# Altium schematic sheet 14-USB_Hub.SchDoc(14-USB_Hub)
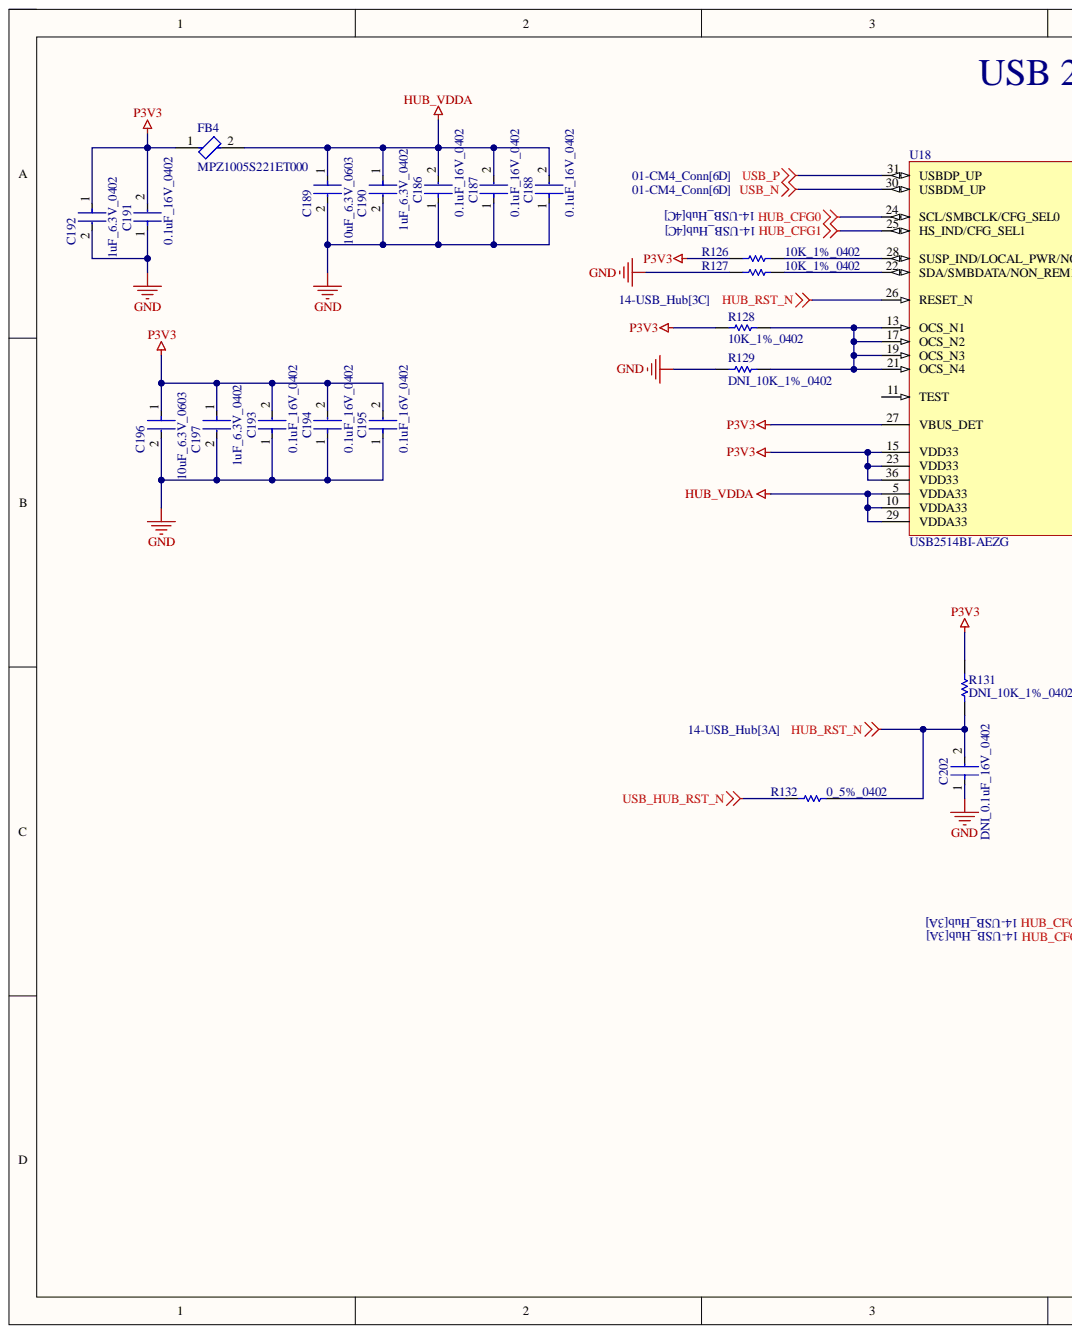
[diagram p0: 14-USB_Hub.SchDoc, left]
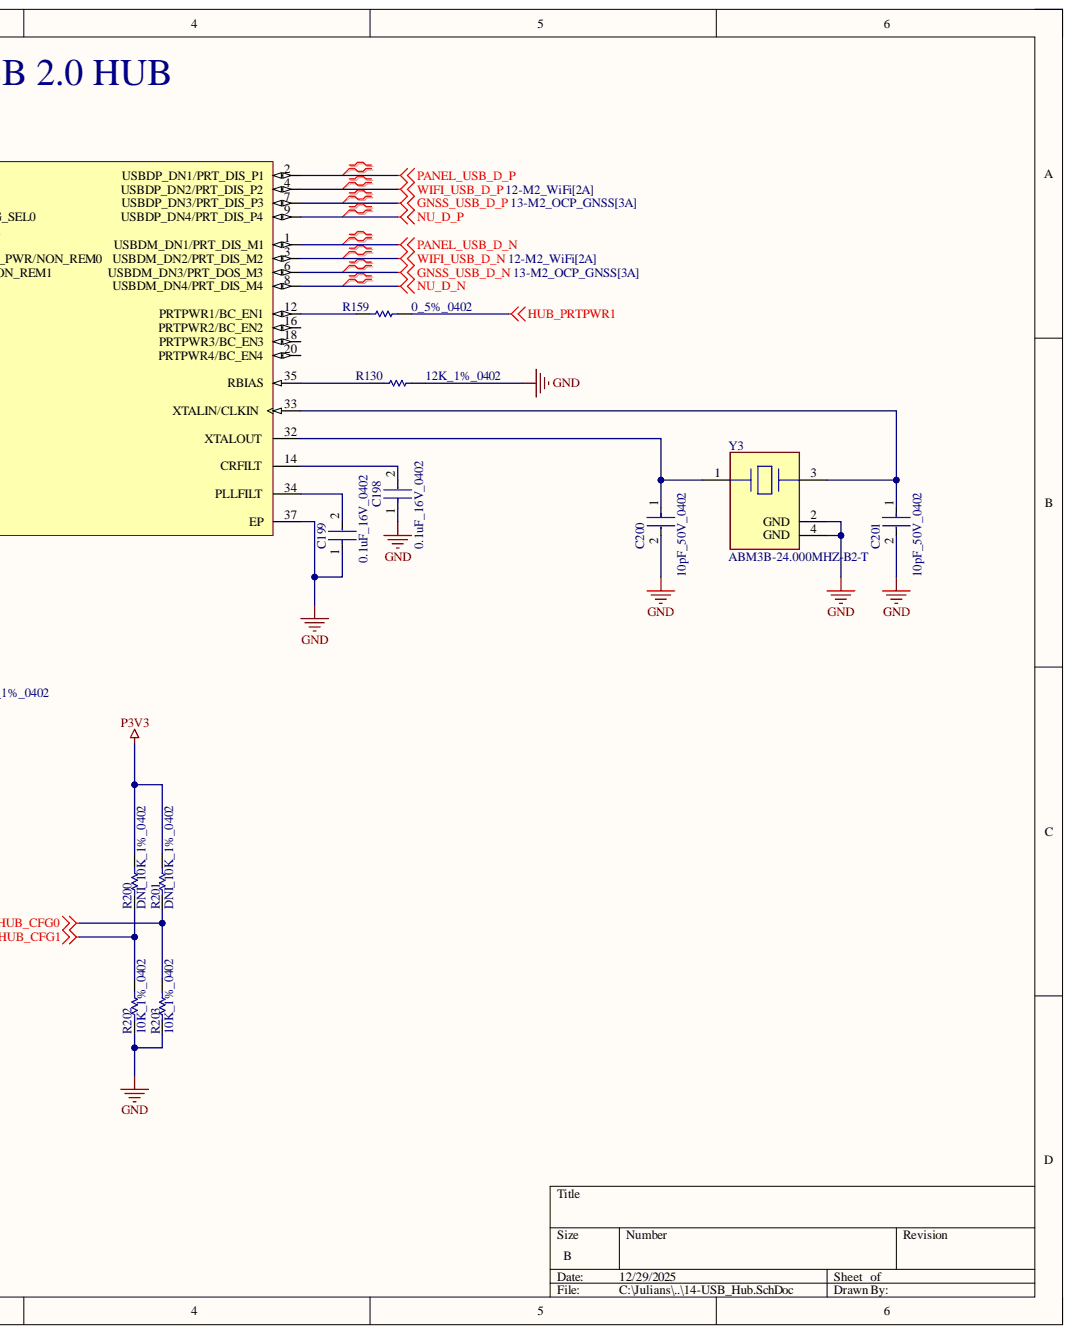
[diagram p1: 14-USB_Hub.SchDoc, right]

## Parts
C186  0.1uF_16V_0402  [FP-C0402-BB-MFG]
C187  0.1uF_16V_0402  [FP-C0402-BB-MFG]
C188  0.1uF_16V_0402  [FP-C0402-BB-MFG]
C189  10uF_6.3V_0603  [FP-GRM188-0_1-e0_2_0_55-IPC_A]
C190  1uF_6.3V_0402  [FP-CC0402-MFG]
C191  0.1uF_16V_0402  [FP-C0402-BB-MFG]
C192  1uF_6.3V_0402  [FP-CC0402-MFG]
C193  0.1uF_16V_0402  [FP-C0402-BB-MFG]
C194  0.1uF_16V_0402  [FP-C0402-BB-MFG]
C195  0.1uF_16V_0402  [FP-C0402-BB-MFG]
C196  10uF_6.3V_0603  [FP-GRM188-0_1-e0_2_0_55-IPC_A]
C197  1uF_6.3V_0402  [FP-CC0402-MFG]
C198  0.1uF_16V_0402  [FP-C0402-BB-MFG]
C199  0.1uF_16V_0402  [FP-C0402-BB-MFG]
C200  10pF_50V_0402  [FP-C0402-BB-MFG]
C201  10pF_50V_0402  [FP-C0402-BB-MFG]
C202  DNI_0.1uF_16V_0402  [FP-C0402-BB-MFG]  (no BOM)
FB4  MPZ1005S221ET000  [FP-MPZ1005-IPC_A]
R126  10K_1%_0402  [RESC1005X40X25NL10T10]
R127  10K_1%_0402  [RESC1005X40X25NL10T10]
R128  10K_1%_0402  [RESC1005X40X25NL10T10]
R129  DNI_10K_1%_0402  [RESC1005X40X25NL10T10]  (no BOM)
R130  12K_1%_0402  [RESC1005X40X25LL10T10]
R131  DNI_10K_1%_0402  [RESC1005X40X25NL10T10]  (no BOM)
R132  0_5%_0402  [RESC1005X40X25NL05T10]
R159  0_5%_0402  [RESC1005X40X25NL05T10]
R200  DNI_10K_1%_0402  [RESC1005X40X25NL10T10]  (no BOM)
R201  DNI_10K_1%_0402  [RESC1005X40X25NL10T10]  (no BOM)
R202  10K_1%_0402  [RESC1005X40X25NL10T10]
R203  10K_1%_0402  [RESC1005X40X25NL10T10]
U18  USB2514BI-AEZG  [MCHP-QFN-36_V]
Y3  ABM3B-24.000MHZ-B2-T  [FP-ABM3B-MFG]

## Nets
GND: C186.1 C187.1 C188.1 C189.2 C190.2 C191.1 C192.2 C193.1 C194.1 C195.1 C196.2 C197.2 C198.1 C199.1 C200.2 C201.2 C202.1 R127.1 R129.1 R130.2 R202.1 R203.1 U18.37(EP) Y3.2(GND) Y3.4(GND)
GNSS_USB_D_N: U18.6(USBDM_DN3/PRT_DOS_M3)
GNSS_USB_D_P: U18.7(USBDP_DN3/PRT_DIS_P3)
HUB_CFG0: R201.1 R203.2 U18.24(SCL/SMBCLK/CFG_SEL0)
HUB_CFG1: R200.1 R202.2 U18.25(HS_IND/CFG_SEL1)
HUB_PRTPWR1: R159.2
HUB_RST_N: C202.2 R131.1 R132.2 U18.26(RESET_N)
HUB_VDDA: C186.2 C187.2 C188.2 C189.1 C190.1 FB4.2 U18.5(VDDA33) U18.10(VDDA33) U18.29(VDDA33)
NU_D_N: U18.8(USBDM_DN4/PRT_DIS_M4)
NU_D_P: U18.9(USBDP_DN4/PRT_DIS_P4)
P3V3: C191.2 C192.1 C193.2 C194.2 C195.2 C196.1 C197.1 FB4.1 R126.1 R128.1 R131.2 R200.2 R201.2 U18.15(VDD33) U18.23(VDD33) U18.27(VBUS_DET) U18.36(VDD33)
PANEL_USB_D_N: U18.1(USBDM_DN1/PRT_DIS_M1)
PANEL_USB_D_P: U18.2(USBDP_DN1/PRT_DIS_P1)
USB_HUB_RST_N: R132.1
USB_N: U18.30(USBDM_UP)
USB_P: U18.31(USBDP_UP)
WIFI_USB_D_N: U18.3(USBDM_DN2/PRT_DIS_M2)
WIFI_USB_D_P: U18.4(USBDP_DN2/PRT_DIS_P2)
NetC198_2: C198.2 U18.14(CRFILT)
NetC199_2: C199.2 U18.34(PLLFILT)
NetC200_1: C200.1 U18.32(XTALOUT) Y3.1
NetC201_1: C201.1 U18.33(XTALIN/CLKIN) Y3.3
NetR126_2: R126.2 U18.28(SUSP_IND/LOCAL_PWR/NON_REM0)
NetR127_2: R127.2 U18.22(SDA/SMBDATA/NON_REM1)
NetR128_2: R128.2 R129.2 U18.13(OCS_N1) U18.17(OCS_N2) U18.19(OCS_N3) U18.21(OCS_N4)
NetR130_1: R130.1 U18.35(RBIAS)
NetR159_1: R159.1 U18.12(PRTPWR1/BC_EN1)

Not connected: U18 11(TEST) 16(PRTPWR2/BC_EN2) 18(PRTPWR3/BC_EN3) 20(PRTPWR4/BC_EN4)

## Library data of the parts
C186-C188 C191 C193-C195 C198 C199 C202 = CMP-1034-02068-3: CAP CER 0.1UF 16V 5% X7R 0402; Manufacturer: Kemet; Manufacturer Part Number: C0402C104J4RACTU; Capacitance: 0.10 μF; Material: Ceramic; Temperature Coefficient: 15%; Tolerance: 0.05; Value: 0.10 μF; Automotive: No; Category: Cap; ComponentLink2Description: Datasheet; ComponentLink2URL: https://content.kemet.com/datasheets/KEM_C1002_X7R_SMD.pdf; Device Class L1: Passive Components; Device Class L2: Capacitors; Device Class L3: Ceramic Capacitors; Digikey Description: CAP CER 0.1UF 16V 5% X7R 0402; Height: 0.55mm; Ipc Land Pattern Name: CAPC10050X50; Lead Free: Yes; Library Name: Altium Content Vault; Mouser Description: CAP CER 0.1UF 16V X7R 0402; Octopart: https://octopart.com/c0402c104j4ractu-kemet-12573893; Package: C0402-BB; RoHS: TRUE; Temperature: -55°C to +125°C; Temperature Characteristic: X7R; Temperature Range High: +125°C; Temperature Range Low: -55°C; Voltage Rating: 16 V
  C186-C188 C191 C193-C195 C198 C199: Component Kind: Standard
C189 C196 = CMP-2006-02792-2: Chip Multilayer Ceramic Capacitors for General Purpose, 0603, 10uF, X5R, 15%, 20%, 6.3V; Capacitance: 10uF; Case Code (Imperial): 0603; Case Code (Metric): 1608; Case/Package: 0603; Component Kind: Standard; Depth: 0.8mm; Dielectric: X5R; Height: 0.8mm; Lead Free: Lead Free; Length: 1.6mm; Library Name: Altium Content Vault; Material: Ceramic; Max Operating Temperature: 85°C; Min Operating Temperature: -55°C; Mount: Surface Mount; Package Quantity: 4000; Packaging: Tape and Reel; Radiation Hardening: No; REACH SVHC: No SVHC; Termination: SMD/SMT; Thickness: 0.035inch; Tolerance: 20%; Voltage: 6.3V; Voltage Rating: 6.3V; Voltage Rating (DC): 6.3V; Width: 0.031inch
C190 C192 C197 = CMP-2100-03591-2: CAP CER 1UF 6.3V X5R 0402; Manufacturer: YAGEO; Manufacturer Part Number: CC0402KRX5R5BB105; Automotive Grade: NA; Material: Ceramic; Temperature Coefficient: 30ppm/°C; Tolerance: 0.1; Automotive: No; Capacitance: 1 uF; Category: Cap; Component Kind: Standard; ComponentLink2Description: Datasheet; ComponentLink2URL: http://www.yageo.com/documents/recent/UPY-GP_NP0_16V-to-50V_16.pdf; Device Class L1: Passive Components; Device Class L2: Capacitors; Device Class L3: Ceramic Capacitors; Digikey Description: CAP CER 1UF 6.3V X5R 0402; Footprint Url: http://www.yageo.com/exep/pages/download/literatures/UPY-C_GEN_24.pdf; Height: 0.55mm; Ipc Land Pattern Name: CAPC10050X50; Lead Free: Yes; Library Name: Altium Content Vault; Mouser Description: Multilayer Ceramic Capacitors MLCC - SMD/SMT 1uF 6.3V X5R 10%; Octopart: https://octopart.com/cc0402krx5r5bb105-yageo-42244618; Package: CC0402; RoHS: TRUE; Temperature: -55°C to +125°C; Temperature Characteristic: X5R; Temperature Range High: +125°C; Temperature Range Low: -55°C; Value: 1 uF; Voltage Rating: 6.3 V
C200 C201 = CMP-2008-03404-2: Multilayer Ceramic Capacitor, C Series, 10 Pf, - 5%, C0G / Np0, 50 V, 0402 [1005 Metric] Rohs Compliant: Yes; Manufacturer: Kemet; Manufacturer Part Number: C0402C100J5GACTU; Capacitance: 10 pF; Temperature Coefficient: 15%; Tolerance: 0.05; Value: 10 pF; Voltage Rating: 50 V; Automotive: No; Category: Cap; Component Kind: Standard; ComponentLink2Description: Datasheet; ComponentLink2URL: https://content.kemet.com/datasheets/KEM_C1002_X7R_SMD.pdf; Device Class L1: Passive Components; Device Class L2: Capacitors; Device Class L3: Ceramic Capacitors; Digikey Description: Multilayer Ceramic Capacitor, C Series, 10 Pf, - 5%, C0G / Np0, 50 V, 0402 [1005 Metric] Rohs Compliant: Yes; Height: 0.55mm; Ipc Land Pattern Name: CAPC10050X50; Lead Free: Yes; Library Name: Altium Content Vault; Material: Ceramic; Mouser Description: Multilayer Ceramic Capacitor, C Series, 10 Pf, - 5%, C0G / Np0, 50 V, 0402 [1005 Metric] Rohs Compliant: Yes; Octopart: https://octopart.com/c0402c100j5gactu-kemet-108448; Package: C0402-BB; RoHS: TRUE; Temperature: -55°C to +125°C; Temperature Characteristic: C0G; Temperature Range High: +125°C; Temperature Range Low: -55°C
FB4 = CMP-08257-000226-1: Power line Ferrite Bead 220Ω @ 100MHz 0.9A DCR 0.22Ω SMD 0402; DC Resistance (DCR): 220mR; Impedance: 220R; Max Current Rating: 900mA; Number of Lines: 1; Tolerance: 25%; Case Code (Imperial): 0402; Case Code (Metric): 1005; Case/Package: 0402; Component Kind: Standard; Core Material: Ferrite; Height: 0.022inch; Length: 0.039inch; Library Name: Altium Content Vault; Max DC Current: 900mA; Max Operating Temperature: 125°C; Min Operating Temperature: -55°C; Mount: Surface Mount; Package Quantity: 10000; Packaging: Tape and Reel; RoHS Compliant: Yes; Width: 0.02inch
R126-R129 R131 R200-R203 = CMP-2002-04694-1: Manufacturer: Bourns; Part Number: CR0402-FX-1002GLF; Library Name: Altium Content Vault
  R126-R128 R202 R203: Component Kind: Standard
R130 = CMP-2002-05686-1: Manufacturer: TE Connectivity / AMP; Part Number: CRG0402F12K; Component Kind: Standard; Library Name: Altium Content Vault
R132 R159 = CMP-2002-06457-1: Manufacturer: Panasonic; Part Number: ERJ-U020R00X; Component Kind: Standard; Library Name: Altium Content Vault
U18 = CMP-2000-05218-1: USB 2.0 Hi-Speed Hub Controller, 3 to 3.6 V, -40 to 85 degC, 36-Pin QFN, RoHS, Tape and Reel; Manufacturer: Microchip; Auxiliary Sense: -40degC~85degC; Current - Supply: 85mA; Dynamic Catalog: USBControllers; Internal Switch(s): 3V~3.6V; Other Names: Q5138631  Q5487824  Q7033177  USB2514BIAEZG; Standards: USB2.0; Category: IntegratedCircuits(ICs); Component Kind: Standard; Datasheet URL: https://datasheet.ciiva.com/22650/1691496-22650784.pdf; Datasheet Version: Rev. 2.2, 02/2012; Family: Interface-Controllers; Function: HubController; Interface: USB; Library Name: Altium Content Vault; Manufacturer URL: http://www.microchip.com/; Minimum Quantity: USB; Operating Temperature: -40degC~85degC; Package / Case: 36-TQFNExposedPad; Packaging: Tube; Protocol: USB; Quantity Available: Tube; Standard Package: 490; Supplier Device Package: 36-QFN-EP(6x6); Type: USB; Voltage - Supply: 3V~3.6V
Y3 = CMP-1688-00008-2: Crystal 24MHz ±20ppm 18pF SMD-4 5mm x 3.2mm; ESR (Equivalent Series Resistance): 50R; Frequency Stability: 50ppm; Case/Package: CSMD; Component Kind: Standard; Depth: 3.2mm; Frequency: 24MHz; Frequency Tolerance: 20ppm; Height: 0.043inch; Lead Free: Lead Free; Length: 5mm; Library Name: Altium Content Vault; Load Capacitance: 18pF; Max Operating Temperature: 70°C; Min Operating Temperature: -20°C; Mount: Surface Mount; Number of Pins: 4; Operating Frequency: 24 MHz; Package Quantity: 1000; Packaging: Tape and Reel; REACH SVHC: No SVHC; Resistor Type: Ceramic; Series: ABM3B; Series Resistance: 50R; Termination: SMD/SMT; Tolerance: 20ppm; Width: 0.126inch
